# Altium schematic sheet Motor Control - Phase A.SchDoc("Motor Control - Phase A")
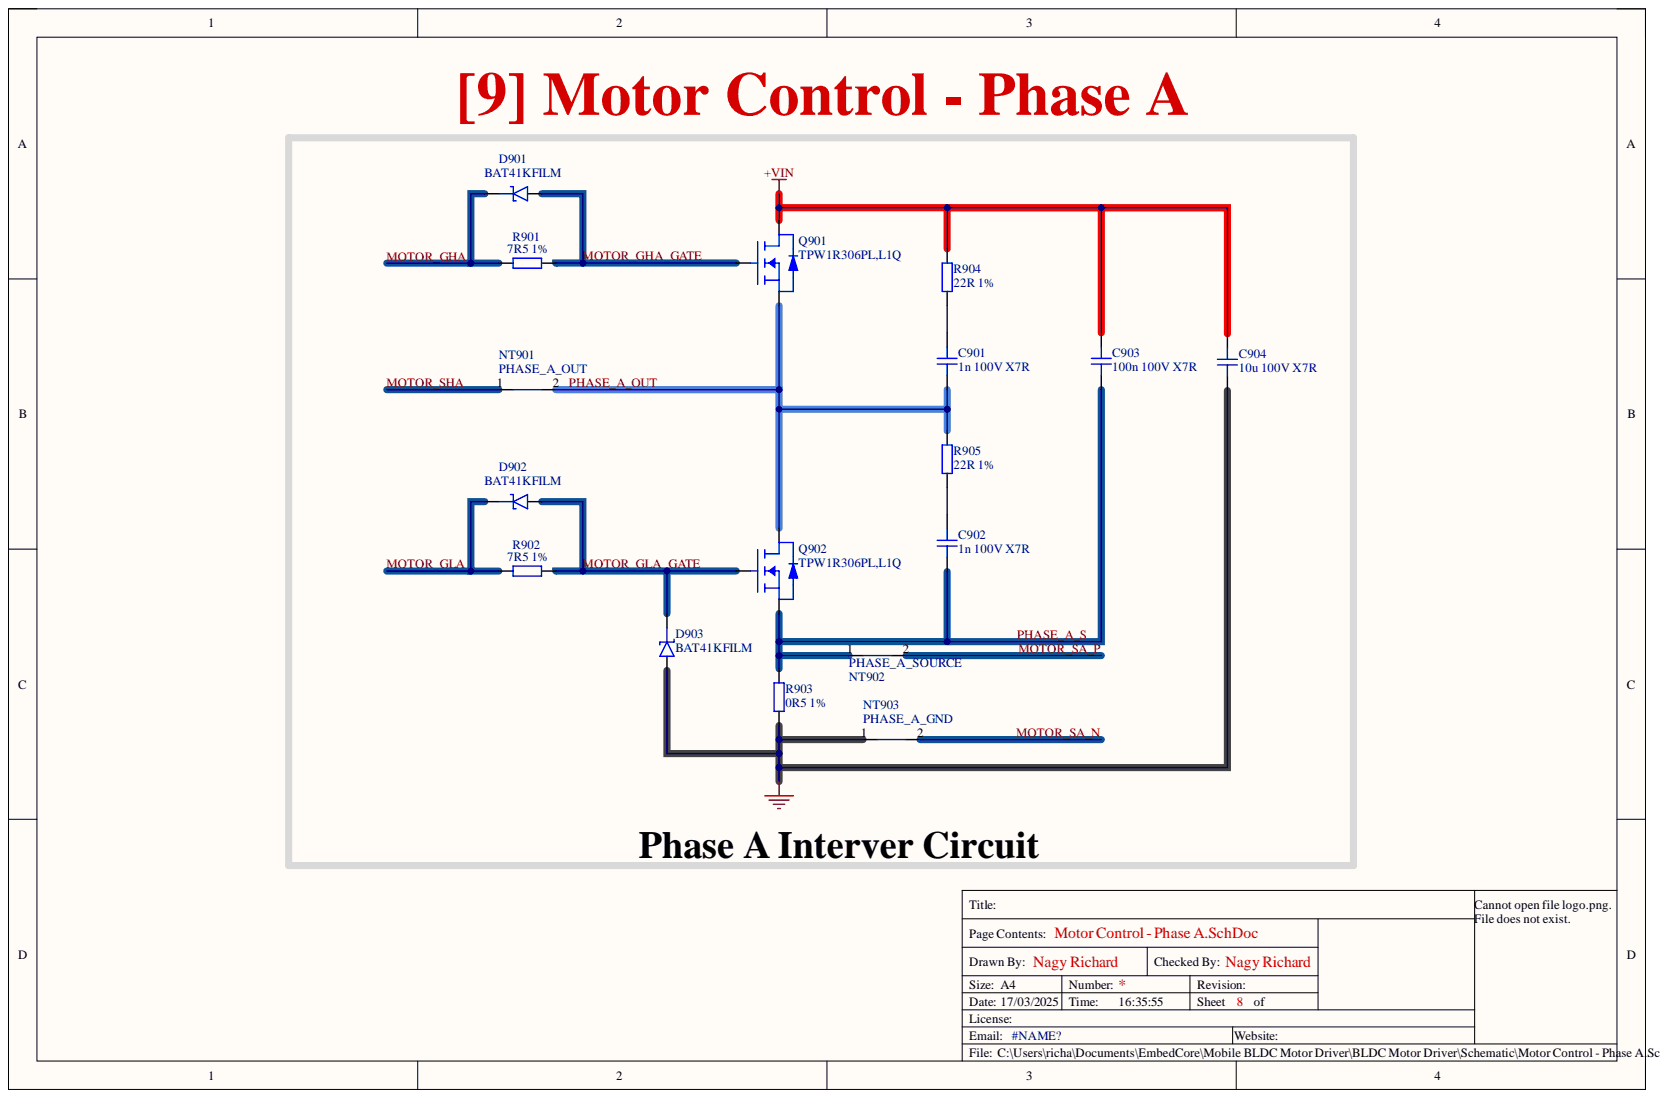
[diagram p0: Motor Control - Phase A.SchDoc]

## Parts
C901  1n 100V X7R  [CAP_0805]
C902  1n 100V X7R  [CAP_0805]
C903  100n 100V X7R  [CAP_1206]
C904  10u 100V X7R  [CAP_1210]
D901  BAT41KFILM  [SOD-523]
D902  BAT41KFILM  [SOD-523]
D903  BAT41KFILM  [SOD-523]
NT901  PHASE_A_OUT  [NETTIE_SMD]
NT902  PHASE_A_SOURCE  [NETTIE_SMD]
NT903  PHASE_A_GND  [NETTIE_SMD]
Q901  TPW1R306PL,L1Q  [PowerPAK-SO-8]
Q902  TPW1R306PL,L1Q  [PowerPAK-SO-8]
R901  7R5 1%  [RES_0603]
R902  7R5 1%  [RES_0603]
R903  0R5 1%  [RES_1206]
R904  22R 1%  [RES_0805]
R905  22R 1%  [RES_0805]

## Nets
+VIN: C903.2 C904.2 Q901.D R904.2
GND: C904.1 D903.A NT903.1 R903.1
MOTOR_GHA: D901.K R901.1
MOTOR_GHA_GATE: D901.A Q901.G R901.2
MOTOR_GLA: D902.K R902.1
MOTOR_GLA_GATE: D902.A D903.K Q902.G R902.2
MOTOR_SA_N: NT903.2
MOTOR_SA_P: NT902.2
MOTOR_SHA: NT901.1
PHASE_A_OUT: C901.1 NT901.2 Q901.S Q902.D R905.2
PHASE_A_S: C902.1 C903.1 NT902.1 Q902.S R903.2
NetC901_2: C901.2 R904.1
NetC902_2: C902.2 R905.1

## Library data of the parts
C901 C902 = CAP_0805: Capacitor SMD 0805; Manufacturer: Kyocera AVX; Manufacturer Part Number: 08051C102JAT2A; Component Kind: Standard; Distributor Link: www.lcsc.com; LCSC: C2169492; Library Name: Schematic-Lib-PhilsLab.SchLib
C903 = CAP_1206: Capacitor SMD 1206; Manufacturer: YAGEO; Manufacturer Part Number: CC1206JKX7R0BB104; Component Kind: Standard; Distributor Link: www.lcsc.com; LCSC: C726629; Library Name: Schematic-Lib-PhilsLab.SchLib
C904 = CAP_1210: Capacitor SMD 1210; Manufacturer: PSA(Prosperity Dielectrics); Manufacturer Part Number: FS32X106K101EGG; Component Kind: Standard; Distributor Link: www.lcsc.com; LCSC: C5156756; Library Name: Schematic-Lib-PhilsLab.SchLib
D901-D903 = D_SCHOTTKY_SOD_523: Diode Schottky SMD SOD-323; Manufacturer: STMicroelectronics; Component Kind: Standard; LCSC: C73150; Manufacturer Part Number: BAT41KFILM; Library Name: Schematic-Lib-PhilsLab.SchLib
NT901-NT903 = NetTie_SMD: Net Tie SMD; Component Kind: Net Tie; Library Name: Schematic-Lib-PhilsLab.SchLib
Q901 Q902 = SIR500DP-T1-RE3: MOSFET N-Channel Vds=30V Id=351A Rds=470uR; Manufacturer: Vishay; Component Kind: Standard; Manufacturer Part Number: SIR500DP-T1-RE3; Library Name: Schematic-Lib-PhilsLab.SchLib
R901 R902 = RES_0603: Resistor SMD 0603; Manufacturer: PANASONIC; Manufacturer Part Number: ERJPA3J7R5V; Component Kind: Standard; Distributor Link: www.lcsc.com; LCSC: C445790; Library Name: Schematic-Lib-PhilsLab.SchLib
R903 = RES_1206: Resistor SMD 1206; Manufacturer: Milliohm; Manufacturer Part Number: HoSRX1206-3W-0.5mR-1%; Component Kind: Standard; Distributor Link: www.lcsc.com; LCSC: C18724161; Library Name: Schematic-Lib-PhilsLab.SchLib
R904 R905 = RES_0805: Resistor SMD 0805; Manufacturer: Viking Tech; Manufacturer Part Number: ARG05FTC0220; Component Kind: Standard; Distributor Link: www.lcsc.com; LCSC: C218436; Library Name: Schematic-Lib-PhilsLab.SchLib
